# Altium schematic sheet Sheet_Power.SchDoc
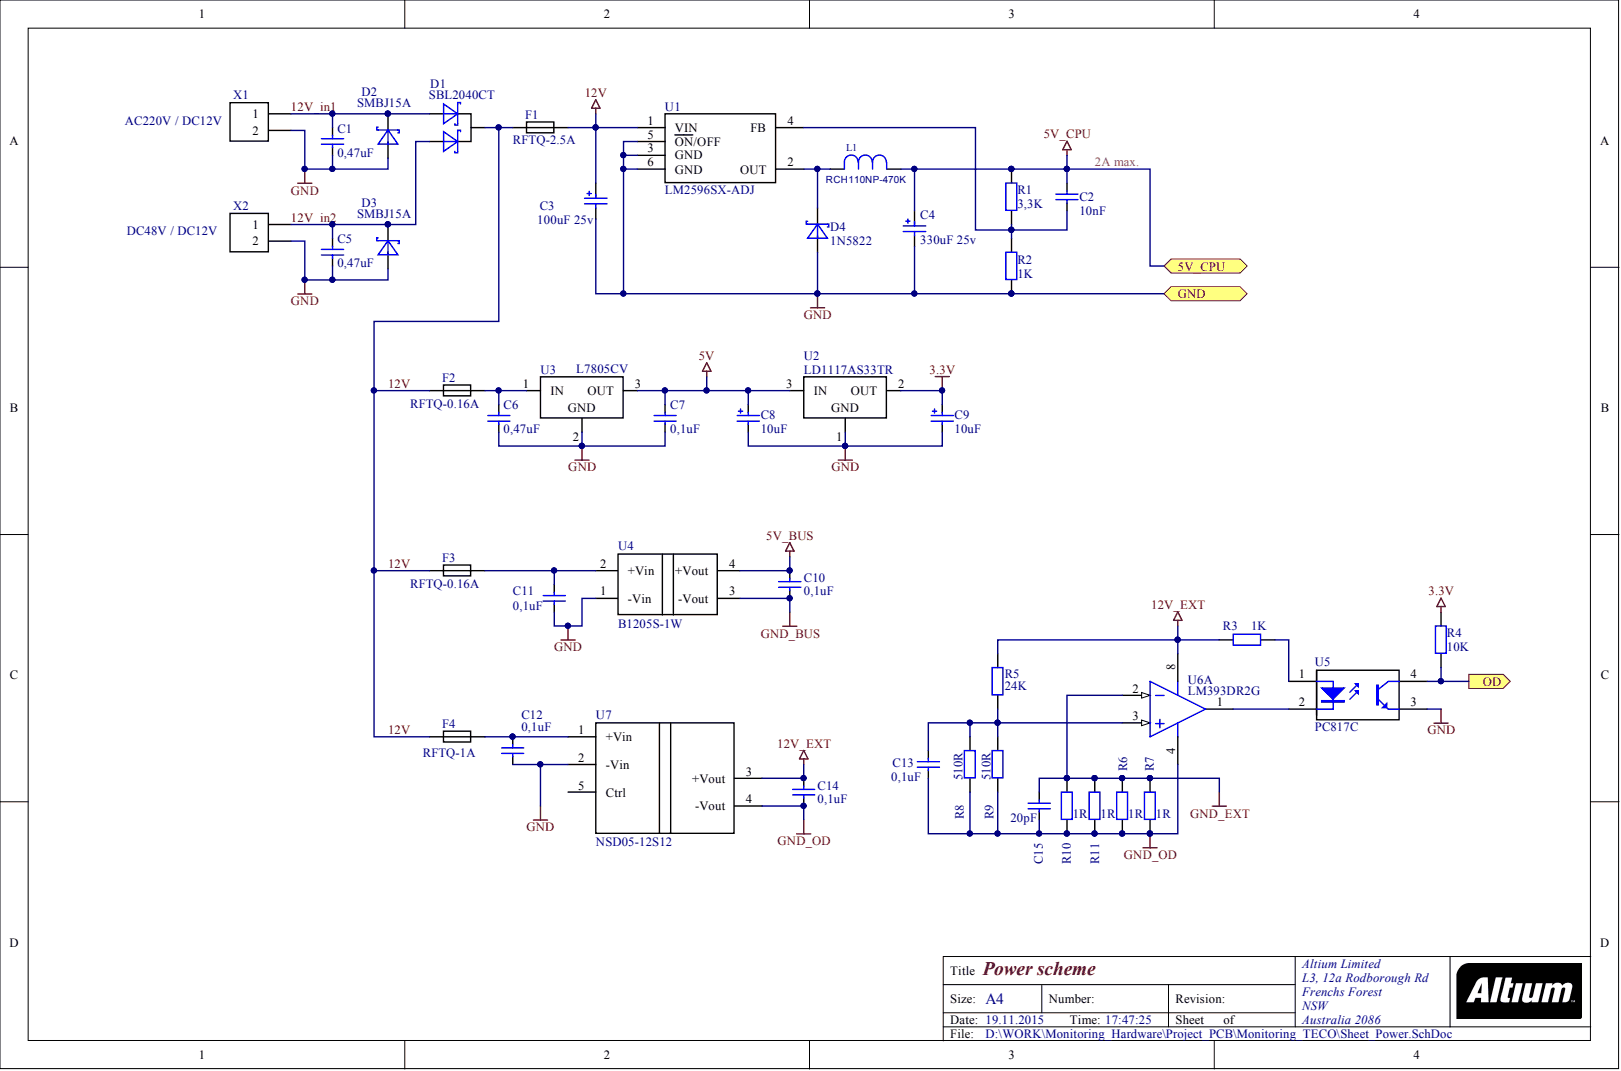
[diagram p0: Sheet_Power.SchDoc]

## Parts
C1  0,47uF  [C_1206]
C2  10nF  [C_0805]
C3  value 100uF 25v  [8x11]  (hidden comment: Cap_Polar)
C4  value 330uF 25v  [10x16]  (hidden comment: Cap_Polar)
C5  0,47uF  [C_1206]
C6  0,47uF  [C_0805]
C7  0,1uF  [C_0805]
C8  10uF  [5x11]
C9  10uF  [5x11]
C10  0,1uF  [C_0805]
C11  0,1uF  [C_0805]
C12  0,1uF  [C_1206]
C13  0,1uF  [C_0805]
C14  0,1uF  [C_1206]
C15  20pF  [C_0805]
D1  SBL2040CT  [TO-220A_VR]
D2  SMBJ15A  [SMB]
D3  SMBJ15A  [SMB]
D4  1N5822  [DO-201_Vertical]
F1  RFTQ-2.5A  [Fuse RFTQ]
F2  RFTQ-0.16A  [Fuse RFTQ]
F3  RFTQ-0.16A  [Fuse RFTQ]
F4  RFTQ-1A  [Fuse RFTQ]
L1  RCH110NP-470K  [RCH-110]
R1  value 3,3K  [R_0805]  (hidden comment: Resistor)
R2  value 1K  [R_0805]  (hidden comment: Resistor)
R3  value 1K  [R_0805]  (hidden comment: Resistor)
R4  value 10K  [R_0805]  (hidden comment: Resistor)
R5  value 24K  [R_0805]  (hidden comment: Resistor)
R6  value 1R  [R_0805]  (hidden comment: Resistor)
R7  value 1R  [R_0805]  (hidden comment: Resistor)
R8  value 510R  [R_0805]  (hidden comment: Resistor)
R9  value 510R  [R_0805]  (hidden comment: Resistor)
R10  value 1R  [R_0805]  (hidden comment: Resistor)
R11  value 1R  [R_0805]  (hidden comment: Resistor)
U1  LM2596SX-ADJ  [D2PAK_5p]
U2  LD1117AS33TR  [SOT-223_N]
U3  L7805CV  [TO-220A_VR]
U4  B1205S-1W  [SIP4]
U5  PC817C  [DIP-4]
U6  LM393DR2G  [SO-8_L]  (part A)
U7  NSD05-12S12  [NSD05]
X1  DG300_2pin  [5mm 2]  (value not drawn)
X2  DG300_2pin  [5mm 2]  (value not drawn)

## Ports
5V_CPU, GND, OD

## Nets
3.3V: C9.1 R4.2 U2.2
5V: C7.2 C8.1 U2.3 U3.3
5V_BUS: C10.1 U4.4(+Vout)
12V_EXT: C14.2 R3.1 R5.2 U6.8 U7.3(+Vout)
GND_BUS: C10.2 U4.3(-Vout)
GND_EXT: C15.2 R6.2 R7.2 R10.2 R11.2 U6.2
GND_OD: C13.1 C14.1 C15.1 R6.1 R7.1 R8.1 R9.1 R10.1 R11.1 U6.4 U7.4(-Vout)
5V_CPU: C2.1 C4.1 L1.1 R1.2 ; port 5V_CPU
12V: C3.1 D1.2 F1.1 F1.2 F2.2 F3.2 F4.2 U1.1(VIN)
12V_IN1: C1.2 D1.1 D2.2 X1.1
12V_IN2: C5.2 D1.3 D3.2 X2.1
GND: C1.1 C3.2 C4.2 C5.1 C6.1 C7.1 C8.2 C9.2 C11.2 C12.1 D2.1 D3.1 D4.1 R2.1 U1.3(GND) U1.5(ON/OFF) U1.6(GND) U2.1 U3.2 U4.1(-Vin) U5.3 U7.2(-Vin) X1.2 X2.2 ; port GND
OD: R4.1 U5.4 ; port OD
NetC2_2: C2.2 R1.1 R2.2 U1.4(FB)
NetC6_2: C6.2 F2.1 U3.1
NetC11_1: C11.1 F3.1 U4.2(+Vin)
NetC12_2: C12.2 F4.1 U7.1(+Vin)
NetC13_2: C13.2 R5.1 R8.2 R9.2 U6.3
NetD4_2: D4.2 L1.2 U1.2(OUT)
NetR3_2: R3.2 U5.1
NetU5_2: U5.2 U6.1

Not connected: U7 5(Ctrl)

## Library data of the parts
C1 C2 C5-C7 C10-C15 = Cap_Semi: Capacitor semi; Component Kind: Standard; Library Name: Capacitor.SchLib
C3 C4 = Cap_Polar: Capacitor Polar; Component Kind: Standard; Library Name: Capacitor.SchLib
C8 C9 = Capacitor Polar: Component Kind: Standard; Description: Capacitor polar; Library Name: Capacitor.SchLib
D1 = SBL2040CT: Diode Schottky, Dual rectifier; Component Kind: Standard; Library Name: Diode.SchLib
D2 D3 = SMBJ13A: Supressor; Component Kind: Standard; Library Name: Diode.SchLib
D4 = 1N5822: Diode Schottky; Component Kind: Standard; Library Name: Diode.SchLib
F1-F4 = Fuse: Component Kind: Standard; Description: Fuse; Library Name: Other.SchLib
L1 = Inductor: Component Kind: Standard; Description: Inductor; Value: RCH110NP-470K; Library Name: Induction.SchLib
U1 = LM2596SX-ADJ: Easy Switcher 3.0A Step-Down Voltage Regulator; Component Kind: Standard; Library Name: Power.SchLib
U2 = LD1117AS33TR: Voltage Regulators 800mA; Component Kind: Standard; Library Name: Power.SchLib
U3 = L7805CV: Precision 1A Regulator; Component Kind: Standard; ComponentLink1Description: Manufacturer Link; ComponentLink1URL: http://www.st.com/; ComponentLink2Description: Datasheet; ComponentLink2URL: http://www.st.com/stonline/products/literature/ds/2144/l7805ab.pdf; DatasheetVersion: Nov-1999; LatestRevisionDate: 17-Jul-2002; LatestRevisionNote: Re-released for DXP Platform.; Library Name: Power.SchLib; PackageDescription: TO, Thru-Hole, Vertical, Heatsink Mounted; 3 In-Line Leads; Pitch 2.54 mm; Pin sequence 1, 3, 2; PackageReference: TO220ABN; PackageVersion: Jun-1998; Published: 24-Aug-2001; Publisher: Altium Limited
U4 = B0505S-1W: DC/DC converter; Component Kind: Standard; Library Name: Power.SchLib
U5 = PC817: Optocoupler; Component Kind: Standard; Library Name: Chip_Packaging.SchLib
U6 = LM393DR2G: Dual Comparators; Component Kind: Standard; Library Name: Chip_Packaging.SchLib
U7 = NSD05-12S12: DC/DC Regulated Single Output; Component Kind: Standard; Library Name: Power.SchLib
X1 X2 = DG300_2pin: Connector 5mm; Component Kind: Standard; Library Name: Connect.SchLib
R1-R11 = Resistor: Component Kind: Standard; Description: Resistor; Library Name: Resistors.SchLib
